# Altium schematic sheet CAN_LIN.SchDoc
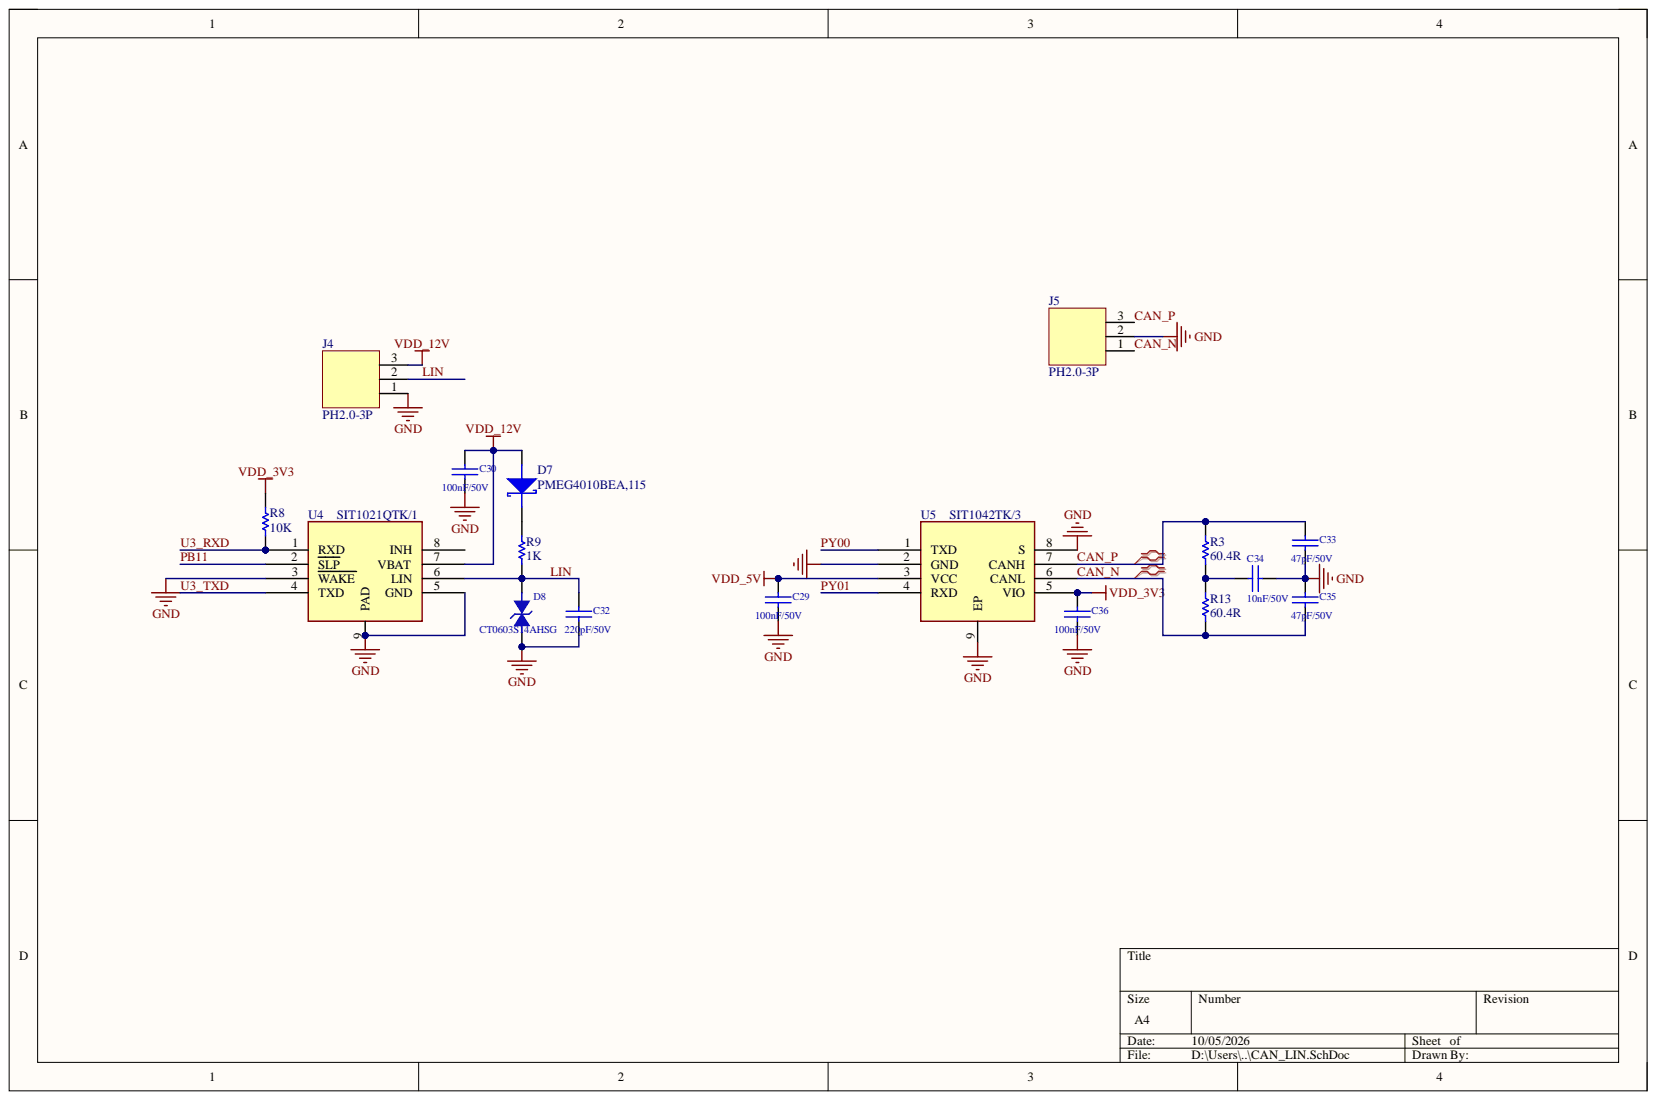
[diagram p0: CAN_LIN.SchDoc]

## Parts
C29  100nF/50V  [C0402]
C30  100nF/50V  [C0402]
C32  220pF/50V  [C0402]
C33  47pF/50V  [C0402]
C34  10nF/50V  [C0402]
C35  47pF/50V  [C0402]
C36  100nF/50V  [C0402]
D7  PMEG4010BEA,115  [SOD-323]
D8  CT0603S14AHSG  [CT0603]
J4  PH2.0-3P  [PH2.0-3P]
J5  PH2.0-3P  [PH2.0-3P]
R3  60.4R  [R0603]
R8  10K  [R0402]
R9  1K  [R0805]
R13  60.4R  [R0603]
U4  SIT1021QTK/1  [HVSON-8-EP(3X3)]
U5  SIT1042TK/3  [HVSON-8-EP(3x3)]

## Nets
GND: C29.2 C30.2 C32.1 C33.1 C34.1 C35.2 C36.2 D8.2 J4.1 J5.2 U4.3(WAKE) U4.5(GND) U4.9(PAD) U5.2(GND) U5.8(S) U5.9(EP)
VDD_3V3: C36.1 R8.1 U5.5(VIO)
VDD_5V: C29.1 U5.3(VCC)
VDD_12V: C30.1 D7.A J4.3 U4.7(VBAT)
CAN_N: C35.1 J5.1 R13.2 U5.6(CANL)
CAN_P: C33.2 J5.3 R3.1 U5.7(CANH)
LIN: C32.2 D8.1 J4.2 R9.1 U4.6(LIN)
PB11: U4.2(SLP)
PY00: U5.1(TXD)
PY01: U5.4(RXD)
U3_RXD: R8.2 U4.1(RXD)
U3_TXD: U4.4(TXD)
NetC34_2: C34.2 R3.2 R13.1
NetD7_K: D7.K R9.2

Not connected: U4 8(INH)

## Library data of the parts
C29 C30 = Cap: Capacitor; Manufacturer: SAMSUNG(三星); JCL-Code: C307331; Name: Capacitance; PreferredModel: CL05B104KB54PNC; WeldingType: SMD; Component Kind: Standard; Library Name: My_Integrated_Library.SchLib
C32 = Cap: Capacitor; Manufacturer: Murata; InternalNumbering: GRD1205; JCL-Code: C388904; Name: Capacitance; PreferredModel: GCM155R71H221KA37D; WeldingType: SMD; Component Kind: Standard; Library Name: My_Integrated_Library.SchLib
C33 C35 = Cap: Capacitor; Manufacturer: Murata; InternalNumbering: GRD1510; JCL-Code: C126511; Name: Capacitance; PreferredModel: GCM1555C1H470JA16D; WeldingType: SMD; Component Kind: Standard; Library Name: My_Integrated_Library.SchLib
C34 = Cap: Capacitor; Manufacturer: Murata; InternalNumbering: GRD1126; JCL-Code: C91601; Name: Capacitance; PreferredModel: GCM155R71H103KA55D; WeldingType: SMD; Component Kind: Standard; Library Name: My_Integrated_Library.SchLib
C36 = Cap: Capacitor; Manufacturer: Murata; InternalNumbering: GRD1123; JCL-Code: C85858; Name: Capacitance; PreferredModel: GCM155R71H104KE02D; WeldingType: SMD; Component Kind: Standard; Library Name: My_Integrated_Library.SchLib
D7 = Schottky Diode: Manufacturer: Nexperia; JCL-Code: C36975; WeldingType: SMD; Component Kind: Standard; Description: Schottky Diode; Library Name: My_Integrated_Library.SchLib; Name: Schottky Diode; PreferredModel: PMEG4010BEA,115
D8 = 1SMB18CAT3G: -40～150℃/AEC-Q200; Manufacturer: TDK; InternalNumbering: GRD1091; Name: CTVS; PreferredModel: B72500E8140S160; WeldingType: SMD-NC; Component Kind: Standard; Library Name: My_Integrated_Library.SchLib
R3 R13 = Res: Resistance; Manufacturer: YAGEO; InternalNumbering: GRD1496; JCL-Code: C144807; PreferredModel: AC0603FR-0760R4L; WeldingType: SMD; Component Kind: Standard; Library Name: My_Integrated_Library.SchLib; Name: Resistance
R8 = Res: Resistance; Manufacturer: YAGEO; InternalNumbering: GRD1049; JCL-Code: C144807; PreferredModel: AC0402FR-0710KL; WeldingType: SMD; Component Kind: Standard; Library Name: My_Integrated_Library.SchLib; Name: Resistance
R9 = Res: Resistance; Manufacturer: Yageo; JCL-Code: C140870; PreferredModel: AC0805FR-071KL; WeldingType: SMD; Component Kind: Standard; Library Name: My_Integrated_Library.SchLib; Name: Resistance
U4 = SIT1021QTK/1: 12V LIN/-40~150℃/AEC-Q100; Manufacturer: SIT(芯力特); Name: LIN Transceiver; WeldingType: SMD; Component Kind: Standard; Library Name: My_Integrated_Library.SchLib; PreferredModel: SIT1021QTK/1
U5 = SIT1042TK/3: 5M CAN FD/-40~125℃/AEC-Q100; Manufacturer: SIT; JCL-Code: C38695; Name: CAN Transceiver; WeldingType: SMD; Component Kind: Standard; Library Name: My_Integrated_Library.SchLib; PreferredModel: SIT1042TK/3
J4 J5 = PH2.0-3P: Component Kind: Standard; Library Name: PH.SchLib
